# Altium schematic sheet 03-10715_Rev_0.1_Sheet_5.SchDoc
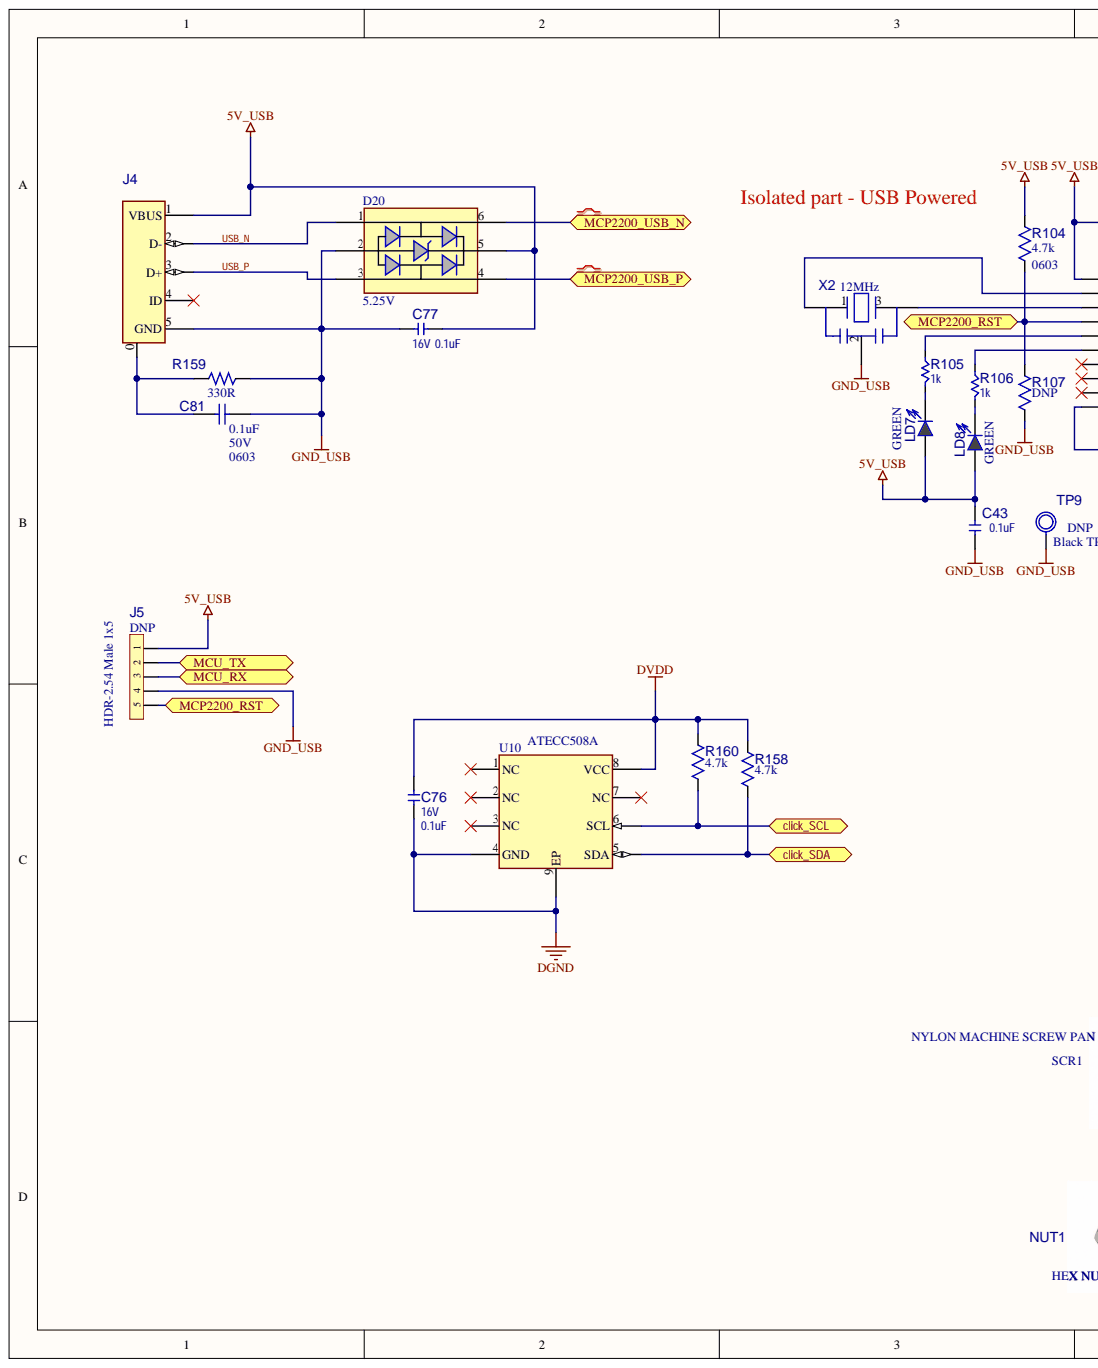
[diagram p0: 03-10715_Rev_0.1_Sheet_5.SchDoc, left]
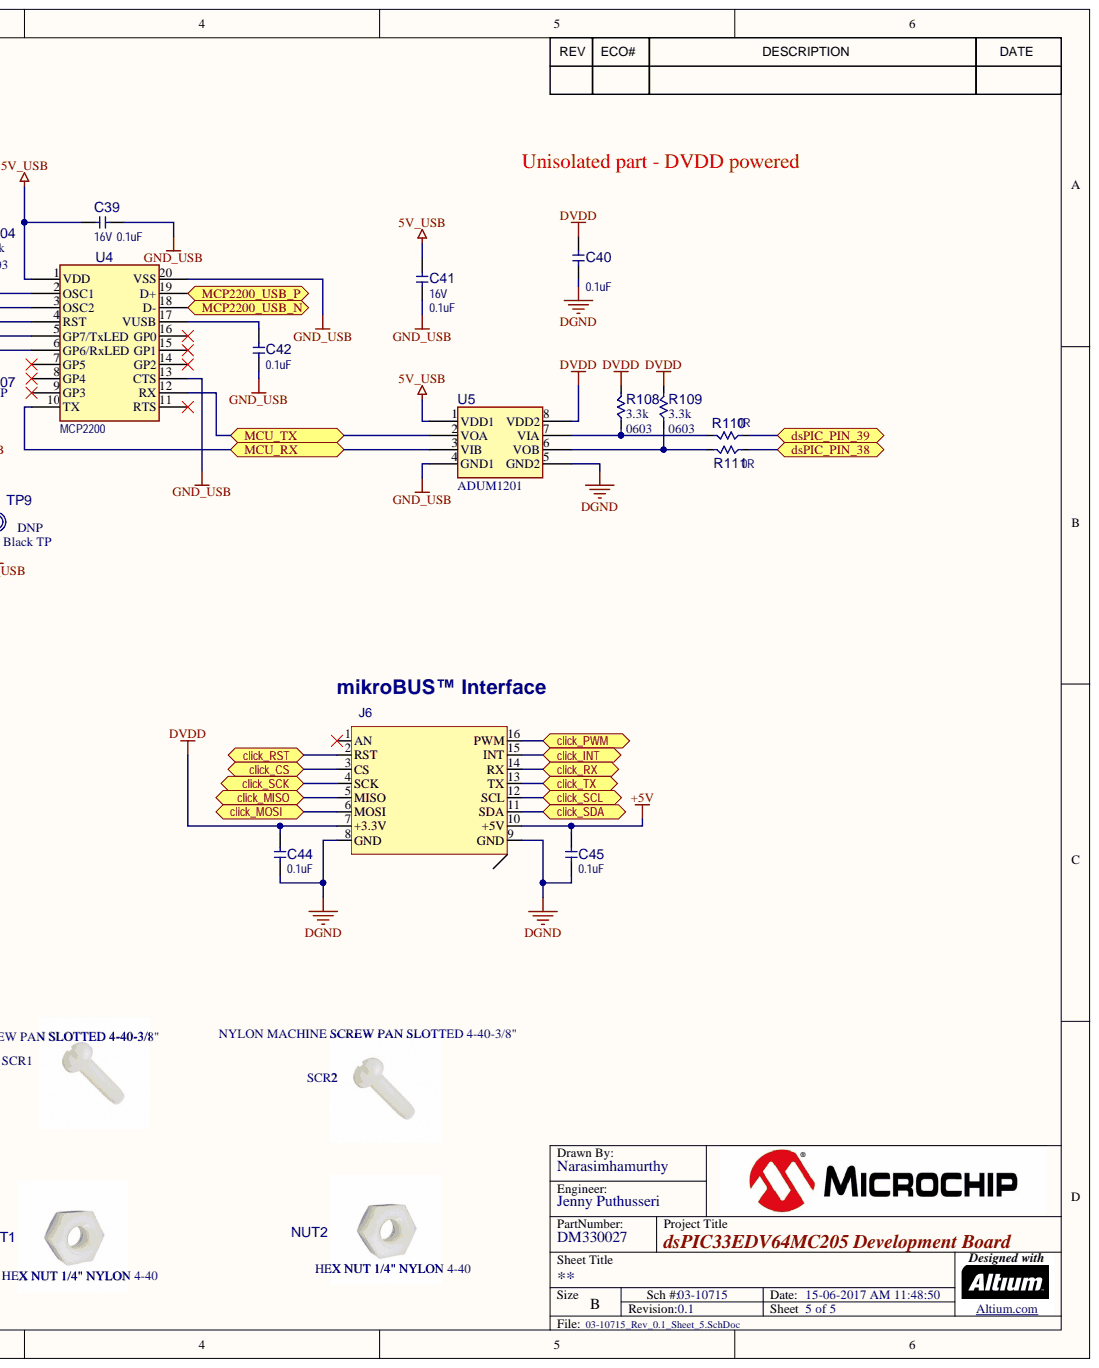
[diagram p1: 03-10715_Rev_0.1_Sheet_5.SchDoc, right]

## Parts
C39  value 0.1uF  Voltage: 16V  [CAP_C_0603]  (hidden comment: Capacitor NP)
C40  value 0.1uF  [CAP_C_0603]  (hidden comment: Capacitor NP)
C41  value 0.1uF  Voltage: 16V  [CAP_C_0603]  (hidden comment: Capacitor NP)
C42  value 0.1uF  [CAP_C_0603]  (hidden comment: Capacitor NP)
C43  value 0.1uF  [CAP_C_0603]  (hidden comment: Capacitor NP)
C44  value 0.1uF  [CAP_C_0603]  (hidden comment: Capacitor NP)
C45  value 0.1uF  [CAP_C_0603]  (hidden comment: Capacitor NP)
C76  value 0.1uF  Voltage: 16V  [CAP_C_0603]  (hidden comment: Capacitor NP)
C77  value 0.1uF  Voltage: 16V  [CAP_C_0603]  (hidden comment: Capacitor NP)
C81  value 0.1uF  Package: 0603  Voltage: 50V  [CAP_C_0603]  (hidden comment: Capacitor NP)
D20  value 5.25V  Reverse Voltage: 5.25V  [SOT-23-6]  (hidden comment: DIODE TVS USBLC6-2 SOT-23-6)
J4  value 5  [CON_USB-B_Mini_SMD_RA_HIR_UX60-MB-5ST]  (hidden comment: CON USB-B)
J5  value HDR-2.54 Male 1x5  Populated: DNP  [HDR_M_2.54_1x5]  (hidden comment: Header 1x5)
J6  CON mikroBUS ALT  [SOCKET mikroBUS Host ALT]  (value not drawn)
LD7  value GREEN  [LED_0603_GREEN]  (hidden comment: LED_green)
LD8  value GREEN  [LED_0603_GREEN]  (hidden comment: LED_green)
NUT1  Description_: HEX NUT 1/4" NYLON 4-40  (hidden comment: Nut Hex Nylon 4-40)  (mechanical)
NUT2  Description_: HEX NUT 1/4" NYLON 4-40  (hidden comment: Nut Hex Nylon 4-40)  (mechanical)
R104  value 4.7k  Package: 0603  [RES_C_0603]  (hidden comment: Resistor)
R105  value 1k  [RES_C_0603]  (hidden comment: Resistor)
R106  value 1k  [RES_C_0603]  (hidden comment: Resistor)
R107  Populated: DNP  [RES_C_0603]  (hidden comment: Resistor)
R108  value 3.3k  Package: 0603  [RES_C_0603]  (hidden comment: Resistor)
R109  value 3.3k  Package: 0603  [RES_C_0603]  (hidden comment: Resistor)
R110  Resistor  [RES_C_0603]  (value not drawn)
R111  value 0R  [RES_C_0603]  (hidden comment: Resistor)
R158  value 4.7k  [RES_C_0603]  (hidden comment: Resistor)
R159  value 330R  [RES_C_0603]  (hidden comment: Resistor)
R160  value 4.7k  [RES_C_0603]  (hidden comment: Resistor)
SCR1  Screw Nylon PAN SLOTTED 4-40-3_8  (value not drawn)
SCR2  Screw Nylon PAN SLOTTED 4-40-3_8  (value not drawn)
TP9  value Black TP  Populated: DNP  [CON_TP_TH_V_BLK_KEC_5011]  (hidden comment: Test Point)
U4  value MCP2200  [SSOP-20_P0.65]  (hidden comment: MCP2200 SSOP-20)
U5  ADUM1201  [SOIC-8]
U10  value ATECC508A  [UDFN-8_2X3_EP1.5X1.3]  (hidden comment: ATECC508A UDFN-8)
X2  value 12MHz  [RESONATOR_CSTCE-G_L3.2W1.3H0.8]  (hidden comment: Resonator 3 Pins)

## Ports
click_CS, click_INT, click_MISO, click_MOSI, click_PWM, click_RST, click_RX, click_SCK, click_SCL, click_SDA, click_TX, dsPIC_PIN_38, dsPIC_PIN_39, MCP2200_RST, MCP2200_USB_N, MCP2200_USB_P, MCU_RX, MCU_TX

## Nets
5V_USB: C39.1 C41.1 C43.1 C77.2 D20.5 J4.1(VBUS) J5.1 LD7.1 LD8.1 R104.2 U4.1(VDD) U5.1(VDD1)
+5V: C45.1 J6.10(+5V)
DGND: C40.2 C44.2 C45.2 C76.1 J6.8(GND) J6.9(GND) U5.5(GND2) U10.4(GND) U10.9(EP)
DVDD: C40.1 C44.1 C76.2 J6.7(+3.3V) R108.1 R109.1 R158.1 R160.1 U5.8(VDD2) U10.8(VCC)
GND_USB: C39.2 C41.2 C42.1 C43.2 C77.1 C81.2 D20.2 J4.5(GND) J5.4 R107.1 R159.2 TP9.1 U4.13(CTS) U4.20(VSS) U5.4(GND1) X2.2
click_CS: J6.3(CS) ; port click_CS
click_INT: J6.15(INT) ; port click_INT
click_MISO: J6.5(MISO) ; port click_MISO
click_MOSI: J6.6(MOSI) ; port click_MOSI
click_PWM: J6.16(PWM) ; port click_PWM
click_RST: J6.2(RST) ; port click_RST
click_RX: J6.14(RX) ; port click_RX
click_SCK: J6.4(SCK) ; port click_SCK
click_SCL: J6.12(SCL) R160.2 U10.6(SCL) ; port click_SCL
click_SDA: J6.11(SDA) R158.2 U10.5(SDA) ; port click_SDA
click_TX: J6.13(TX) ; port click_TX
dsPIC_PIN_38: R111.2 ; port dsPIC_PIN_38
dsPIC_PIN_39: R110.2 ; port dsPIC_PIN_39
MCP2200_RST: J5.5 R104.1 R107.2 U4.4(RST) ; port MCP2200_RST
MCP2200_USB_N: D20.6 U4.18(D-) ; port MCP2200_USB_N
MCP2200_USB_P: D20.4 U4.19(D+) ; port MCP2200_USB_P
MCU_RX: J5.3 U4.10(TX) U5.3(VIB) ; port MCU_RX
MCU_TX: J5.2 U4.12(RX) U5.2(VOA) ; port MCU_TX
USB_N: D20.1 J4.2(D-)
USB_P: D20.3 J4.3(D+)
NetC42_2: C42.2 U4.17(VUSB)
NetC81_1: C81.1 J4.0 R159.1
NetLD7_2: LD7.2 R105.1
NetLD8_2: LD8.2 R106.1
NetR105_2: R105.2 U4.5(GP7/TxLED)
NetR106_2: R106.2 U4.6(GP6/RxLED)
NetR108_2: R108.2 R110.1 U5.7(VIA)
NetR109_2: R109.2 R111.1 U5.6(VOB)
NetU4_2: U4.2(OSC1) X2.1
NetU4_3: U4.3(OSC2) X2.3

Not connected: J4 4(ID); J6 1(AN); U4 7(GP5) 8(GP4) 9(GP3) 11(RTS) 14(GP2) 15(GP1) 16(GP0); U10 1(NC) 2(NC) 3(NC) 7(NC)

## Library data of the parts
C39 C41 C76 C77 = CAP0011: Capacitor; Manufacturer 1: NIC Components; Manufacturer Part Number 1: NMC0603X7R104K16TRPF; Description_: CAP CER 0.1uF 16V 10% X7R SMD 0603; Populated: Yes; Temperature Coeffcient: X7R; Tolerance: 10%; Type: Ceramic; Category: Capacitor; Component Kind: Standard; ComponentLink1Description: Datasheet; ComponentLink1URL: https://chn-vm-apps.microchip.com/mchprepo/parts/Datasheets/nmc2.pdf; Database Table Name: Capacitor; Library Name: MCHP-CDB.DbLib; Manufacturer 2: Panasonic; Manufacturer 3: Taiyo Yuden; Manufacturer 4: Yageo; Manufacturer 5: Murata; Manufacturer 6: AVX; Manufacturer Part Number 2: ECJ-1VB1C104K; Manufacturer Part Number 3: EMK107B7104KA-T; Manufacturer Part Number 4: CC0603KRX7R7BB104; Manufacturer Part Number 5: GRM188R71C104KA01D; Manufacturer Part Number 6: 0603YC104KAT2A; MCHP-ID: CAP0011; Operating Temperature: -55C to +125C; Package: 0603; Quantity Override: 1; Revision: 3; Status: Production; Supplier 1: NIC Components; Supplier 2: Digi-Key; Supplier 3: Digi-Key; Supplier 4: Newark; Supplier 5: Digi-Key; Supplier 6: Digi-Key; Supplier 7: Digi-Key; Supplier Part Number 1: NMC0603X7R104K16TRPF; Supplier Part Number 2: PCC1762CT-ND; Supplier Part Number 3: 587-1240-2-ND; Supplier Part Number 4: 86H5248; Supplier Part Number 5: 311-1088-1-ND; Supplier Part Number 6: 490-1532-1-ND; Supplier Part Number 7: 478-1239-1-ND
C40 C42-C45 = CAP0011: Capacitor; Manufacturer 1: NIC Components; Manufacturer Part Number 1: NMC0603X7R104K16TRPF; Description_: CAP CER 0.1uF 16V 10% X7R SMD 0603; Populated: Yes; Temperature Coeffcient: X7R; Tolerance: 10%; Type: Ceramic; Voltage: 16V; Category: Capacitor; Component Kind: Standard; ComponentLink1Description: Datasheet; ComponentLink1URL: https://chn-vm-apps.microchip.com/mchprepo/parts/Datasheets/nmc2.pdf; Database Table Name: Capacitor; Library Name: MCHP-CDB.DbLib; Manufacturer 2: Panasonic; Manufacturer 3: Taiyo Yuden; Manufacturer 4: Yageo; Manufacturer 5: Murata; Manufacturer 6: AVX; Manufacturer Part Number 2: ECJ-1VB1C104K; Manufacturer Part Number 3: EMK107B7104KA-T; Manufacturer Part Number 4: CC0603KRX7R7BB104; Manufacturer Part Number 5: GRM188R71C104KA01D; Manufacturer Part Number 6: 0603YC104KAT2A; MCHP-ID: CAP0011; Operating Temperature: -55C to +125C; Package: 0603; Quantity Override: 1; Revision: 3; Status: Production; Supplier 1: NIC Components; Supplier 2: Digi-Key; Supplier 3: Digi-Key; Supplier 4: Newark; Supplier 5: Digi-Key; Supplier 6: Digi-Key; Supplier 7: Digi-Key; Supplier Part Number 1: NMC0603X7R104K16TRPF; Supplier Part Number 2: PCC1762CT-ND; Supplier Part Number 3: 587-1240-2-ND; Supplier Part Number 4: 86H5248; Supplier Part Number 5: 311-1088-1-ND; Supplier Part Number 6: 490-1532-1-ND; Supplier Part Number 7: 478-1239-1-ND
C81 = CAP0455: Capacitor; Manufacturer 1: AVX; Manufacturer Part Number 1: 06035G104ZAT2A; Description_: CAP CER 0.1uF 50V 20% Y5V SMD 0603; Dev Tools Status: Production; Populated: Yes; Temperature Coeffcient: Y5V; Tolerance: 20%; Type: Ceramic; Category: Capacitor; Component Kind: Standard; ComponentLink1Description: SVN Datasheet; ComponentLink1URL: https://chn-vm-apps.microchip.com/mchprepo/parts/Datasheets/ECJ__General_.pdf; ComponentLink2Description: Local Datasheet; ComponentLink3Description: Open Symbol; ComponentLink4Description: Open Footprint; ComponentLink5Description: Open Footprint 2; ComponentLink6Description: Open Footprint 3; ComponentLink7Description: Open Footprint 4; Database Table Name: Capacitor; Library Name: MCHP-CDB.DbLib; Manufacturer 2: Murata; Manufacturer 3: Panasonic; Manufacturer 4: TDK; Manufacturer 5: Yageo; Manufacturer Part Number 2: GRM188F51H104ZA01D; Manufacturer Part Number 3: ECJ-1VF1H104Z; Manufacturer Part Number 4: C1608Y5V1H104Z; Manufacturer Part Number 5: CC0603ZRY5V9BB104; MCHP-ID: CAP0455; Operating Temperature: -30C to +85C; Quantity Override: 1; Revision: 1; Status: MCL Design; Supplier 1: Digi-Key; Supplier 2: Digi-Key; Supplier 3: Digi-Key; Supplier 4: Digi-Key; Supplier 5: Digi-Key; Supplier 6: Digi-Key; Supplier Part Number 1: 478-3724-1-ND; Supplier Part Number 2: 490-1568-2-ND; Supplier Part Number 3: PCC2153CT-ND; Supplier Part Number 4: 445-1324-1-ND; Supplier Part Number 5: 311-1343-2-ND; Supplier Part Number 6: 311-1343-6-ND; Symbol: Capacitor NP; ComponentLink2URL: C:\ALTIUM_WORK\00001_MCHP-CDB\Datasheets\ECJ__General_.pdf; ComponentLink3URL: C:\ALTIUM_WORK\00001_MCHP-CDB\Library\LibraryRefs\Capacitor NP.SchLib; ComponentLink4URL: C:\ALTIUM_WORK\00001_MCHP-CDB\Library\Footprints\CAP_C_0603.PcbLib
D20 = DIODE1148: Manufacturer 1: STMicroelectronics; Manufacturer Part Number 1: USBLC6-2SC6; Description_: DIO TVSARR USBLC6-2SC6 5.25V SMD SOT-23-6; Dev Tools Status: Production; Owner: Bogdan Popescu; Populated: YES; Type: TVS Array; Category: Diode; Component Kind: Standard; ComponentLink1Description: SVN Datasheet; ComponentLink1URL: https://chn-vm-apps.microchip.com/mchprepo/parts/Datasheets/CD00050750.pdf; ComponentLink2Description: Local Datasheet; ComponentLink3Description: Open Symbol; ComponentLink4Description: Open Footprint; ComponentLink5Description: Open Footprint 2; ComponentLink6Description: Open Footprint 3; ComponentLink7Description: Open Footprint 4; Database Table Name: Diode; Library Name: MCHP-CDB.DbLib; MCHP-ID: DIODE1148; Operating Temperature: -40C to +125C; Package: SOT-23-6; Quantity Override: 1; Revision: 1; Status: MCL Design; Supplier 1: Digi-Key; Supplier Part Number 1: 497-5235-1-ND; Symbol: DIODE TVS USBLC6-2 SOT-23-6; ComponentLink2URL: C:\ALTIUM_WORK\00001_MCHP-CDB\Datasheets\CD00050750.pdf; ComponentLink3URL: C:\ALTIUM_WORK\00001_MCHP-CDB\Library\LibraryRefs\DIODE TVS USBLC6-2 SOT-23-6.SchLib; ComponentLink4URL: C:\ALTIUM_WORK\00001_MCHP-CDB\Library\Footprints\SOT-23-6.PcbLib
J4 = CON0276: Connector USB; Manufacturer 1: Hirose; Manufacturer Part Number 1: UX60-MB-5ST; Description_: CON USB Mini USB-B Female SMD R/A; Populated: Yes; Category: Connector; Component Kind: Standard; ComponentLink1Description: Datasheet; ComponentLink1URL: https://chn-vm-apps.microchip.com/mchprepo/parts/Datasheets/e24000019.pdf; Database Table Name: Connector; Library Name: MCHP-CDB.DbLib; Manufacturer 2: Oupiin; Manufacturer Part Number 2: 8969-B05C00DBU; MCHP-ID: CON0276; Quantity Override: 1; Revision: 2; Status: Production; Supplier 1: Digi-Key; Supplier 2: Digi-Key; Supplier 3: Oupiin; Supplier Part Number 1: H2959TR-ND; Supplier Part Number 2: H2959CT-ND; Supplier Part Number 3: 8969-B05C00DBU; Type: USB
J5 = CON0416: Manufacturer 1: Samtec; Manufacturer Part Number 1: TSW-105-07-S-S; Database Table Name: Connector; Description_: CON HDR-2.54 Male 1x5 Gold 5.84MH TH VERT; Dev Tools Status: Production; Category: Connector; Component Kind: Standard; ComponentLink1Description: Datasheet; ComponentLink1URL: https://chn-vm-apps.microchip.com/mchprepo/parts/Datasheets/TSW-XXX-XX-XXX-X-XX-XXX-MKT.pdf; Library Name: MCHP-CDB.DbLib; Manufacturer 2: FCI; Manufacturer Part Number 2: 68000-105HLF; MCHP-ID: CON0416; Quantity Override: 1; Revision: 1; Status: MCL Design; Supplier 1: Digi-Key; Supplier 2: Digi-Key; Supplier Part Number 1: SAM1033-05-ND; Supplier Part Number 2: 609-3462-ND; Symbol: Header 1x5; Type: Header 2.54mm
J6 = SKT1050: Manufacturer 1: Sullins Connector Solutions; Manufacturer Part Number 1: PPTC081LFBN-RC; Database Table Name: Socket; Description_: Connector Header 8 Position 0.100" (2.54mm) Tin Through Hole; Dev Tools Status: Design; Owner: Tim Moffat; Populated: YES; Category: Socket; Component Kind: Standard; ComponentLink1Description: Datasheet; ComponentLink1URL: https://chn-vm-apps.microchip.com/mchprepo/parts/Datasheets/mikrobus_specification.pdf; Library Name: MCHP-CDB.DbLib; MCHP-ID: SKT1050; Quantity Override: 2; Revision: A; Status: MCL Design; Supplier 1: Digi-Key; Supplier Part Number 1: S7006-ND; Type: PIN
LD7 LD8 = DIODE1155: LED; Manufacturer 1: Lite-On Inc; Manufacturer Part Number 1: LTST-C191KGKT; Database Table Name: Diode; Description_: DIO LED GREEN 2V 30mA 35mcd Clear SMD 0603; Dev Tools Status: Production; Forward Current: 30mA; Owner: Tad Ahlvers; Populated: YES; Power: 75mW; Reverse Voltage: 5V; Type: LED Single; Category: Diode; Component Kind: Standard; ComponentLink1Description: Datasheet; ComponentLink1URL: https://chn-vm-apps.microchip.com/mchprepo/parts/Datasheets/S_110_LTST-C191KGKT.pdf; Library Name: MCHP-CDB.DbLib; MCHP-ID: DIODE1155; Operating Temperature: -55C to +85C; Quantity Override: 1; Revision: 1; Status: MCL Design; Supplier 1: Digi-Key; Supplier Part Number 1: 160-1446-1-ND; Symbol: LED_green
NUT1 NUT2 = MECH0143: Manufacturer 1: Keystone Electronics; Manufacturer Part Number 1: 9605; Database Table Name: Mechanical; Dev Tools Status: Design; Owner: Ed Kennedy; Populated: YES; Category: Hardware; ComponentLink1Description: SVN Datasheet; ComponentLink1URL: https://chn-vm-apps.microchip.com/mchprepo/parts/Datasheets/9605.PDF; ComponentLink2Description: Local Datasheet; ComponentLink3Description: Open Symbol; ComponentLink4Description: Open Footprint; ComponentLink5Description: Open Footprint 2; ComponentLink6Description: Open Footprint 3; ComponentLink7Description: Open Footprint 4; Library Name: MCHP-CDB.DbLib; MCHP-ID: MECH0143; Quantity Override: 2; Revision: B; Status: MCL Design; Supplier 1: Digikey; Supplier Part Number 1: 36-9605-ND; Symbol: Nut Hex Nylon 4-40; Type: Nut; ComponentLink2URL: C:\ALTIUM_WORK\00001_MCHP-CDB\Datasheets\9605.PDF; ComponentLink3URL: C:\ALTIUM_WORK\00001_MCHP-CDB\Library\LibraryRefs\Nut Hex Nylon 4-40.SchLib
R104 R158 R160 = RSMT0628: Manufacturer 1: Panasonic; Manufacturer Part Number 1: ERJ-3EKF4701V; Description_: RES TKF 4.7k 1% 1/10W SMD 0603; Populated: Yes; Power: 1/10W; Temperature Coeffcient: 100ppm/C; Tolerance: 1%; Voltage: 75V; Category: Resistor; Component Kind: Standard; ComponentLink1Description: Datasheet; ComponentLink1URL: https://chn-vm-apps.microchip.com/mchprepo/parts/Datasheets/AOA0000CE2.pdf; Composition: TKF; Database Table Name: Resistor; Description: Resistor; Library Name: MCHP-CDB.DbLib; MCHP-ID: RSMT0628; Operating Temperature: -55C to +155C; Quantity Override: 1; Revision: 1; Status: Production; Supplier 1: Digi-Key; Supplier Part Number 1: P4.70KHCT-ND; Type: RES SMD
  R158 R160: Package: 0603
R105 R106 = RSMT0138: Manufacturer 1: Panasonic; Manufacturer Part Number 1: ERJ-3EKF1001V; Description_: RES TKF 1k 1% 1/10W SMD 0603; Populated: Yes; Power: 1/10W; Temperature Coeffcient: 100ppm/C; Tolerance: 1%; Voltage: 75V; Category: Resistor; Component Kind: Standard; ComponentLink1Description: Datasheet; ComponentLink1URL: https://chn-vm-apps.microchip.com/mchprepo/parts/Datasheets/AOA0000CE2.pdf; Composition: TKF; Database Table Name: Resistor; Description: Resistor; Library Name: MCHP-CDB.DbLib; Manufacturer 2: ROHM; Manufacturer 3: Vishay; Manufacturer 4: Stackpole Electronics Inc; Manufacturer 5: Yageo; Manufacturer 6: Stackpole Electronics Inc; Manufacturer 7: Stackpole Electronics Inc; Manufacturer Part Number 2: MCR03EZPFX1001; Manufacturer Part Number 3: CRCW06031K00FKEA; Manufacturer Part Number 4: RMCF1/161.0K1%TR; Manufacturer Part Number 5: RC0603FR-071KL; Manufacturer Part Number 6: RMCF0603FT1K00; Manufacturer Part Number 7: RMCF 1/16 1K 1% R; MCHP-ID: RSMT0138; Operating Temperature: -55C to +155C; Package: 0603; Quantity Override: 1; Revision: 2; Status: Production; Supplier 1: Digi-Key; Supplier 2: Digi-Key; Supplier 3: Digi-Key; Supplier 4: Newark; Supplier 5: Stackpole Electronics Inc; Supplier 6: Digi-Key; Supplier 7: Digi-Key; Supplier 8: Digi-Key; Supplier Part Number 1: P1.00KHTR-ND; Supplier Part Number 2: P1.00KHCT-ND; Supplier Part Number 3: RHM1.00KHCT-ND; Supplier Part Number 4: 52K8015; Supplier Part Number 5: RMCF1/161.0K1%TR; Supplier Part Number 6: 311-1.00KHRCT-ND; Supplier Part Number 7: RMCF1/161KFRTR-ND; Supplier Part Number 8: RMCF0603FT1K00TR-ND; Type: RES SMD
R107 = RSMT0628: Manufacturer 1: Panasonic; Manufacturer Part Number 1: ERJ-3EKF4701V; Description_: RES TKF 4.7k 1% 1/10W SMD 0603; Power: 1/10W; Temperature Coeffcient: 100ppm/C; Tolerance: 1%; Value: 4.7k; Voltage: 75V; Category: Resistor; Component Kind: Standard; ComponentLink1Description: Datasheet; ComponentLink1URL: https://chn-vm-apps.microchip.com/mchprepo/parts/Datasheets/AOA0000CE2.pdf; Composition: TKF; Database Table Name: Resistor; Description: Resistor; Library Name: MCHP-CDB.DbLib; MCHP-ID: RSMT0628; Operating Temperature: -55C to +155C; Package: 0603; Quantity Override: 1; Revision: 1; Status: Production; Supplier 1: Digi-Key; Supplier Part Number 1: P4.70KHCT-ND; Type: RES SMD
R108 R109 = RES1157: Manufacturer 1: Panasonic; Manufacturer Part Number 1: ERJ-3EKF3301V; Description_: RES TKF 3.3k 1% 1/10W SMD 0603; Owner: Bogdan P (M91398); Populated: Yes; Power: 1/10W; Temperature Coeffcient: 100ppm/C; Tolerance: 1%; Voltage: 75V; Category: Resistor; Component Kind: Standard; ComponentLink1Description: Datasheet; ComponentLink1URL: https://chn-vm-apps.microchip.com/mchprepo/parts/Datasheets/AOA0000CE2.pdf; Composition: TKF; Database Table Name: Resistor; Description: Resistor; Library Name: MCHP-CDB.DbLib; MCHP-ID: RES1157; Operating Temperature: -55C to +155C; Quantity Override: 1; Revision: 0; Status: Production; Supplier 1: Digi-Key; Supplier Part Number 1: P3.30KHCT-ND; Type: RES SMD
R110 = RSMT0001: Manufacturer 1: NIC Components; Manufacturer Part Number 1: NRC06Z0TRF; Description_: RES TKF 0R 1/10W SMD 0603; Populated: Yes; Power: 1/10W; Value: 0R; Category: Resistor; Component Kind: Standard; ComponentLink1Description: Datasheet; ComponentLink1URL: https://chn-vm-apps.microchip.com/mchprepo/parts/Datasheets/nrc.pdf; Composition: TKF; Database Table Name: Resistor; Description: Resistor; Library Name: MCHP-CDB.DbLib; Manufacturer 2: Panasonic; Manufacturer 3: Panasonic; Manufacturer 4: Stackpole Electronics Inc; Manufacturer 5: Vishay; Manufacturer 6: Stackpole Electronics Inc; Manufacturer 7: Yageo; Manufacturer 8: KOA Speer; Manufacturer 9: ROHM; Manufacturer Part Number 2: ERJ-3GSY0R00V; Manufacturer Part Number 3: ERJ-3GEY0R00V; Manufacturer Part Number 4: RMCF0603ZT0R00; Manufacturer Part Number 5: CRCW06030000Z0EA; Manufacturer Part Number 6: RMCF 1/16 0 R; Manufacturer Part Number 7: RC0603JR-070RL; Manufacturer Part Number 8: RK73Z1JTTP; Manufacturer Part Number 9: MCR03EZPJ000; MCHP-ID: RSMT0001; Operating Temperature: -55C to +155C; Package: 0603; Quantity Override: 1; Revision: 2; Status: Production; Supplier 1: NIC Components; Supplier 2: Digi-Key; Supplier 3: Digi-Key; Supplier 4: Digi-Key; Supplier 5: Digi-Key; Supplier 6: Digi-Key; Supplier 7: Digi-Key; Supplier 8: KOA Speer; Supplier 9: Digi-Key; Supplier Part Number 1: NRC06Z0TRF; Supplier Part Number 2: P0.0GTR-ND; Supplier Part Number 3: RMCF0603ZT0R00TR-ND; Supplier Part Number 4: RMCF0603ZT0R00CT-ND; Supplier Part Number 5: 541-0.0GCT-ND; Supplier Part Number 6: RMCF1/160RTR-ND; Supplier Part Number 7: 311-0.0GRCT-ND; Supplier Part Number 8: RK73Z1JTTP; Supplier Part Number 9: RHM0.0GCT-ND; Type: RES SMD
R111 = RSMT0001: Manufacturer 1: NIC Components; Manufacturer Part Number 1: NRC06Z0TRF; Description_: RES TKF 0R 1/10W SMD 0603; Populated: Yes; Power: 1/10W; Category: Resistor; Component Kind: Standard; ComponentLink1Description: Datasheet; ComponentLink1URL: https://chn-vm-apps.microchip.com/mchprepo/parts/Datasheets/nrc.pdf; Composition: TKF; Database Table Name: Resistor; Description: Resistor; Library Name: MCHP-CDB.DbLib; Manufacturer 2: Panasonic; Manufacturer 3: Panasonic; Manufacturer 4: Stackpole Electronics Inc; Manufacturer 5: Vishay; Manufacturer 6: Stackpole Electronics Inc; Manufacturer 7: Yageo; Manufacturer 8: KOA Speer; Manufacturer 9: ROHM; Manufacturer Part Number 2: ERJ-3GSY0R00V; Manufacturer Part Number 3: ERJ-3GEY0R00V; Manufacturer Part Number 4: RMCF0603ZT0R00; Manufacturer Part Number 5: CRCW06030000Z0EA; Manufacturer Part Number 6: RMCF 1/16 0 R; Manufacturer Part Number 7: RC0603JR-070RL; Manufacturer Part Number 8: RK73Z1JTTP; Manufacturer Part Number 9: MCR03EZPJ000; MCHP-ID: RSMT0001; Operating Temperature: -55C to +155C; Package: 0603; Quantity Override: 1; Revision: 2; Status: Production; Supplier 1: NIC Components; Supplier 2: Digi-Key; Supplier 3: Digi-Key; Supplier 4: Digi-Key; Supplier 5: Digi-Key; Supplier 6: Digi-Key; Supplier 7: Digi-Key; Supplier 8: KOA Speer; Supplier 9: Digi-Key; Supplier Part Number 1: NRC06Z0TRF; Supplier Part Number 2: P0.0GTR-ND; Supplier Part Number 3: RMCF0603ZT0R00TR-ND; Supplier Part Number 4: RMCF0603ZT0R00CT-ND; Supplier Part Number 5: 541-0.0GCT-ND; Supplier Part Number 6: RMCF1/160RTR-ND; Supplier Part Number 7: 311-0.0GRCT-ND; Supplier Part Number 8: RK73Z1JTTP; Supplier Part Number 9: RHM0.0GCT-ND; Type: RES SMD
R159 = RSMT0626: Manufacturer 1: Panasonic; Manufacturer Part Number 1: ERJ-3EKF3300V; Description_: RES TKF 330R 1% 1/10W SMD 0603; Dev Tools Status: Production; Populated: Yes; Power: 1/10W; Temperature Coeffcient: 100ppm/C; Tolerance: 1%; Voltage: 75V; Category: Resistor; Component Kind: Standard; ComponentLink1Description: SVN Datasheet; ComponentLink1URL: https://chn-vm-apps.microchip.com/mchprepo/parts/Datasheets/AOA0000CE2.pdf; ComponentLink2Description: Local Datasheet; ComponentLink3Description: Open Symbol; ComponentLink4Description: Open Footprint; ComponentLink5Description: Open Footprint 2; ComponentLink6Description: Open Footprint 3; ComponentLink7Description: Open Footprint 4; Composition: TKF; Database Table Name: Resistor; Description: Resistor; Library Name: MCHP-CDB.DbLib; Manufacturer 2: Stackpole Electronics Inc; Manufacturer Part Number 2: RMCF0603FT330R; MCHP-ID: RSMT0626; Operating Temperature: -55C to +155C; Package: 0603; Quantity Override: 1; Revision: 2; Status: MCL Design; Supplier 1: Digi-Key; Supplier 2: Digi-Key; Supplier Part Number 1: P330HCT-ND; Supplier Part Number 2: RMCF0603FT330RCT-ND; Symbol: Resistor; Type: RES SMD; ComponentLink2URL: C:\ALTIUM_WORK\00001_MCHP-CDB\Datasheets\AOA0000CE2.pdf; ComponentLink3URL: C:\ALTIUM_WORK\00001_MCHP-CDB\Library\LibraryRefs\Resistor.SchLib; ComponentLink4URL: C:\ALTIUM_WORK\00001_MCHP-CDB\Library\Footprints\RES_C_0603.PcbLib
SCR1 SCR2 = MECH0142: Manufacturer 1: Keystone Electronics; Manufacturer Part Number 1: 9328; Database Table Name: Mechanical; Description_: NYLON MACHINE SCREW PAN SLOTTED 4-40-3/8"; Dev Tools Status: Design; Owner: Ed Kennedy; Populated: YES; Category: Hardware; Component Kind: Standard; ComponentLink1Description: SVN Datasheet; ComponentLink1URL: https://chn-vm-apps.microchip.com/mchprepo/parts/Datasheets/M65p135.pdf; ComponentLink2Description: Local Datasheet; ComponentLink3Description: Open Symbol; ComponentLink4Description: Open Footprint; ComponentLink5Description: Open Footprint 2; ComponentLink6Description: Open Footprint 3; ComponentLink7Description: Open Footprint 4; Library Name: MCHP-CDB.DbLib; MCHP-ID: MECH0142; Quantity Override: 2; Revision: B; Status: MCL Design; Supplier 1: Digikey; Supplier Part Number 1: 36-9328-ND; Symbol: Screw Nylon PAN SLOTTED 4-40-3_8; Type: Screw; ComponentLink2URL: C:\ALTIUM_WORK\00001_MCHP-CDB\Datasheets\M65p135.pdf; ComponentLink3URL: C:\ALTIUM_WORK\00001_MCHP-CDB\Library\LibraryRefs\Screw Nylon PAN SLOTTED 4-40-3_8.SchLib
TP9 = CON1083: Manufacturer 1: Keystone; Manufacturer Part Number 1: 5011; Database Table Name: Connector; Description_: CON TP Black Male TH VERT; Owner: Stephen Humberd; Category: Connector; Component Kind: Standard; ComponentLink1Description: Datasheet; ComponentLink1URL: https://chn-vm-apps.microchip.com/mchprepo/parts/Datasheets/5011.pdf; Description: Test Point; Library Name: MCHP-CDB.DbLib; MCHP-ID: CON1083; Quantity Override: 1; Revision: A; Status: Design; Supplier 1: Digi-Key; Supplier Part Number 1: 5011K-ND; Type: Test Point
U4 = MIC0658: USB; Manufacturer 1: Microchip; Manufacturer Part Number 1: MCP2200-I/SS; Database Table Name: MCHP-Interface; Description_: MCHP INT USB MCP2200 SSOP-20; EEPROM: 256; enumstatpin: Yes; ForceMinOrderQtyMult: False; GPIO: 8; LeadContent: Pb-FREE; LeadCount: 20; MAPS Description: USB to UART Protocol Converter; MinOrderQty: 5; MPC: LEAG17G3X026; OperatingVoltageMax: 5.5; OperatingVoltageMin: 3.0; oscillator: External; PackageWidth: .209in; PackingMedia: TUBE; RxBuffers: 128; Stage: REL; tblDeviceFamilyID: 2705; TempRange: -40C to +85C; USB: Full Speed; usbcompliant: USB 2.0; Category: MCHP-Interface; Component Kind: Standard; ComponentLink1Description: Datasheet; ComponentLink1URL: http://www.microchip.com/stellent/idcplg?IdcService=SS_GET_PAGE&nodeId=1335&dDocName=en546923; IntroductionDate: 2010-03-01; Library Name: MCHP-CDB.DbLib; Manufacturer 2: Microchip; Manufacturer Part Number 2: MCP2200T-I/SS; MCHP-ID: MIC0658; PackageType: SSOP; PHY: Yes; Populated: Yes; Quantity Override: 1; Revision: 2; Status: Production; Supplier 1: Microchip; Supplier 2: Digi-Key; Supplier 3: Microchip; Supplier Part Number 1: MCP2200-I/SS; Supplier Part Number 2: MCP2200-I/SS-ND; Supplier Part Number 3: MCP2200T-I/SS; suspendpin: Yes; TxBuffers: 128; txrxledpins: Yes; Type: USB; uartflow: Yes
U5 = IC1138: Isolator; Manufacturer 1: Analog Devices Inc; Manufacturer Part Number 1: ADUM1201CRZ-RL7; Database Table Name: IC; Description_: IC DGTL ISO 2CH LOGIC 8SOIC; Dev Tools Status: Production; Owner: Sompoch Loboonlert; Populated: YES; Category: IC; Component Kind: Standard; ComponentLink1Description: Datasheet; ComponentLink1URL: https://chn-vm-apps.microchip.com/mchprepo/parts/Datasheets/ADuM1200_1201.pdf; Library Name: MCHP-CDB.DbLib; MCHP-ID: IC1138; Package: SOIC-8; Quantity Override: 1; Revision: 1; Status: MCL Design; Supplier 1: Digi-Key; Supplier Part Number 1: ADUM1201CRZ-RL7CT-ND; Symbol: ADUM1201; Type: Isolator; Value: ADUM1201
U10 = IC1305: Manufacturer 1: Atmel; Manufacturer Part Number 1: ATECC508A-MAHDA-T; Database Table Name: IC; Description_: IC INTERFACE ATECC508A UDFN-8; Dev Tools Status: Production; Owner: Razvan Cristescu; Populated: YES; Category: IC; Component Kind: Standard; ComponentLink1Description: SVN Datasheet; ComponentLink1URL: https://chn-vm-apps.microchip.com/mchprepo/parts/Datasheets/Atmel-8923S-CryptoAuth-ATECC508A-Datasheet-Summary[1].pdf; ComponentLink2Description: Local Datasheet; ComponentLink3Description: Open Symbol; ComponentLink4Description: Open Footprint; ComponentLink5Description: Open Footprint 2; ComponentLink6Description: Open Footprint 3; ComponentLink7Description: Open Footprint 4; Description: ATECC508A UDFN-8; Library Name: MCHP-CDB.DbLib; MCHP-ID: IC1305; Package: UDFN-8; Quantity Override: 1; Revision: 1; Status: MCL Design; Supplier 1: Digi-Key; Supplier Part Number 1: ATECC508A-MAHDA-TCT-ND; Symbol: ATECC508A UDFN-8; Type: Memory; ComponentLink2URL: C:\ALTIUM_WORK\00001_MCHP-CDB\Datasheets\Atmel-8923S-CryptoAuth-ATECC508A-Datasheet-Summary[1].pdf; ComponentLink3URL: C:\ALTIUM_WORK\00001_MCHP-CDB\Library\LibraryRefs\ATECC508A UDFN-8.SchLib; ComponentLink4URL: C:\ALTIUM_WORK\00001_MCHP-CDB\Library\Footprints\UDFN-8_2X3_EP1.5X1.3.PcbLib
X2 = OSC1027: Resonator; Manufacturer 1: Murata; Manufacturer Part Number 1: CSTCE12M0G15L99-R0; Database Table Name: Crystal-Oscillator; Description_: RESONATOR 12MHz 0.1% SMD CSTCE-G; Owner: Bogdan P (M91398); Populated: YES; Tolerance: 0.1%; Category: Crystal/Oscillator; Component Kind: Standard; ComponentLink1Description: Datasheet; ComponentLink1URL: https://chn-vm-apps.microchip.com/mchprepo/parts/Datasheets/p16e.pdf; Library Name: MCHP-CDB.DbLib; MCHP-ID: OSC1027; Operating Temperature: 0C to +70C; Package: CSTCE-G; Quantity Override: 1; Revision: A; Status: Design; Supplier 1: Mouser; Supplier Part Number 1: 81-CSTCE12M0G15L99R0; Type: Resonator
